# Altium schematic sheet Э3("All Documents",Physical)
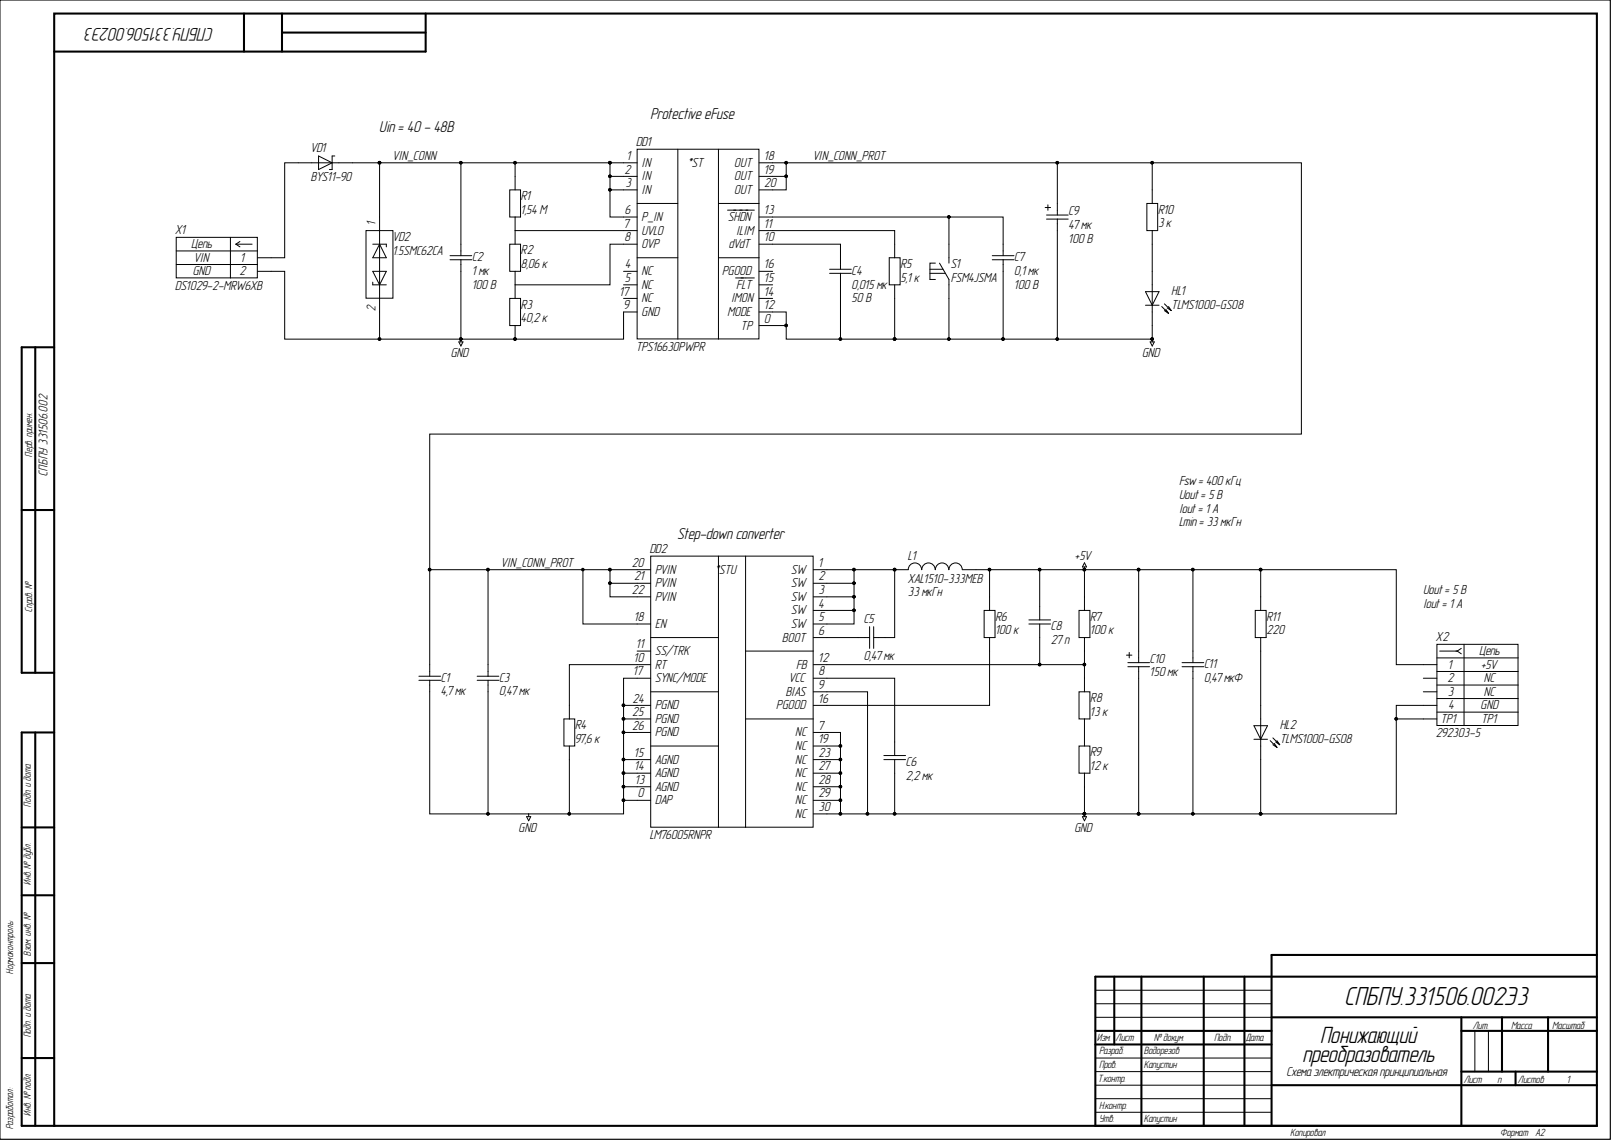
[diagram p0: Э3("All Documents",Physical)]

## Parts
C1  4,7 мк  [C1206]
C2  1 мк  [C1206]
C3  0,47 мк  [C1206]
C4  0,015 мк  Номинальное напряжение: 50 В  [C0603]
C5  0,47 мк  [C1206]
C6  2,2 мк  [C0603]
C7  0,1 мк  Номинальное напряжение: 100 В  [C0603]
C8  27 п  [C0402]
C9  47 мк  Номинальное напряжение: 100 В  [CAPAE-1050X1050X1250]
C10  150 мк  [CAPAE-660X660X580]
C11  0,47 мкФ  [C0402]
DD1  TPS16630PWPR  [HTSSOP-20]
DD2  LM76005RNPR  [WQFN-30]
HL1  TLMS1000-GS08  [HL0603]
HL2  TLMS1000-GS08  [HL0603]
L1  XAL1510-333MEB  Номинал: 33 мкГн  [XAL-2-1620X1520X1100]
R1  1,54 М  [R0603]
R2  8,06 к  [R0603]
R3  40,2 к  [R0603]
R4  97,6 к  [R0603]
R5  5,1 к  [R0603]
R6  100 к  [R0603]
R7  100 к  [R0603]
R8  13 к  [R0603]
R9  12 к  [R0603]
R10  3 к  [R0603]
R11  220  [R0603]
S1  FSM4JSMA  [FSM4JSMA]
VD1  BYS11-90  [DO-214AC]
VD2  1.5SMC62CA  [DO-214AB]
X1  DS1029-2-MRW6XB  Contact_2: GND  Contact_1: VIN  [DS1029-2-MRW6XB]
X2  292303-5  Contact_4: GND  Contact_3: NC  Contact_1: +5V  [292303-5]

## Nets
+5V: C8.2 C10.1 C11.1 L1.2 R6.2 R7.2 R11.2 X2.1
GND: C1.2 C2.2 C3.1 C4.2 C6.1 C7.2 C9.2 C10.2 C11.2 DD1.0(TP) DD1.9(GND) DD1.12(MODE) DD2.0(DAP) DD2.7(NC) DD2.9(BIAS) DD2.13(AGND) DD2.14(AGND) DD2.15(AGND) DD2.17(SYNC/MODE) DD2.19(NC) DD2.23(NC) DD2.24(PGND) DD2.25(PGND) DD2.26(PGND) DD2.27(NC) DD2.28(NC) DD2.29(NC) DD2.30(NC) HL1.1 HL2.1 R3.1 R4.2 R5.2 R9.1 S1.1 VD2.2 X1.2 X2.4 X2.TP1
VIN_CONN: C2.1 DD1.1(IN) DD1.2(IN) DD1.3(IN) DD1.6(P_IN) R1.2 VD1.1 VD2.1
VIN_CONN_PROT: C1.1 C3.2 C9.1 DD1.18(OUT) DD1.19(OUT) DD1.20(OUT) DD2.18(EN) DD2.20(PVIN) DD2.21(PVIN) DD2.22(PVIN) R10.2
NetC4_1: C4.1 DD1.10(dVdT)
NetC5_1: C5.1 DD2.1(SW) DD2.2(SW) DD2.3(SW) DD2.4(SW) DD2.5(SW) L1.1
NetC5_2: C5.2 DD2.6(BOOT)
NetC6_2: C6.2 DD2.8(VCC)
NetC7_1: C7.1 DD1.13(SHDN) S1.2
NetC8_1: C8.1 DD2.12(FB) R7.1 R8.2
NetDD1_4: DD1.4(NC)
NetDD1_5: DD1.5(NC)
NetDD1_7: DD1.7(UVLO) R1.1 R2.2
NetDD1_8: DD1.8(OVP) R2.1 R3.2
NetDD1_11: DD1.11(ILIM) R5.1
NetDD1_14: DD1.14(IMON)
NetDD1_15: DD1.15(FLT)
NetDD1_16: DD1.16(PGOOD)
NetDD1_17: DD1.17(NC)
NetDD2_10: DD2.10(RT) R4.1
NetDD2_11: DD2.11(SS/TRK)
NetDD2_16: DD2.16(PGOOD) R6.1
NetHL1_2: HL1.2 R10.1
NetHL2_2: HL2.2 R11.1
NetR8_1: R8.1 R9.2
NetVD1_2: VD1.2 X1.1
NetX2_2: X2.2
NetX2_3: X2.3

## Library data of the parts
C1 = c0043: =Описание; Фамилия: Водорезов; Рабочие температуры: от -55 °С до +125 °С; Производитель: Samsung electro-mechanics; Отклонение: ±10 %; Описание: Конденсатор чип 1206 4,7 мкФ 100 В ±10 %; Номинальное напряжение: 100 В; Наименование: CL31B475KAHVPNE; Корпус: 1206; Database Table Name: Конденсаторы$; Номинал: 4,7 мк; ID: c0043; Description: Конденсатор чип 1206 4,7 мкФ 100 В ±10 %; Component Kind: Standard; Library Name: Database_Students.DbLib
C2 = c0024: =Описание; Фамилия: Ларионов; Рабочие температуры: от -55 °С до +125 °С; Производитель: YAGEO Corp.; Отклонение: ±10 %; Описание: Конденсатор чип 1206 1 мкФ 100 В ±10 %; Номинальное напряжение: 100 В; Наименование: CC1206KKX7R0BB105; Корпус: 1206; Database Table Name: Конденсаторы$; Номинал: 1 мк; Напряжение: 100 В; ID: c0024; HelpURL: https://www.yageo.com/upload/media/product/productsearch/datasheet/mlcc/UPY-NP0X7R_MV_100-to-630V_22.pdf; Description: Конденсатор чип 1206 1 мкФ 100 В ±10 %; Component Kind: Standard; Library Name: Database_Students.DbLib
C3 C5 = c0008: =Описание; Фамилия: Водорезов; Рабочие температуры: от -55 °С до +125 °С; Производитель: Samsung electro-mechanics; Отклонение: ±10 %; Описание: Конденсатор чип 1206 0,47 мкФ 100 В ±10 %; Номинальное напряжение: 100 В; Наименование: CL31B474KCHWPNE; Корпус: 1206; Database Table Name: Конденсаторы$; Номинал: 0,47 мк; ID: c0008; HelpURL: http://product.samsungsem.com/mlcc/CL31B474KCHWPN.do; Description: Конденсатор чип 1206 0,47 мкФ 100 В ±10 %; Component Kind: Standard; Library Name: Database_Students.DbLib
C4 = c0014: =Описание; Фамилия: Жернаков; Рабочие температуры: от -55 °С до +125 °С; Производитель: YAGEO Corp.; Отклонение: ±5 %; Описание: Конденсатор чип 0603 0,015 мкФ 50 В ±5 %; Наименование: CC0603JPX7R9BB153; Корпус: 0603; Database Table Name: Конденсаторы$; Номинал: 0,015 мк; Напряжение: 50 В; ID: c0014; HelpURL: https://www.yageo.com/upload/media/product/productsearch/datasheet/mlcc/UPY-GPHC_X7R_6.3V-to-50V_20.pdf; Description: Конденсатор чип 0603 0,015 мкФ 50 В ±5 %; Component Kind: Standard; Library Name: Database_Students.DbLib
C6 = c0012: =Описание; Фамилия: Самарин; Рабочие температуры: от -55 °С до +85 °С; Производитель: YAGEO Corp.; Отклонение: ±10 %; Описание: Конденсатор чип 0603 2,2 мкФ 50 В ±10 %; Номинальное напряжение: 50 В; Наименование: CC0603KRX5R9BB225; Корпус: 0603; Database Table Name: Конденсаторы$; Номинал: 2,2 мк; ID: c0012; HelpURL: https://www.yageo.com/upload/media/product/productsearch/datasheet/mlcc/UPY-GPHC_X5R_4V-to-50V_26.pdf; Description: Конденсатор чип 0603 2,2 мкФ 50 В ±10 %; Component Kind: Standard; Library Name: Database_Students.DbLib
C7 = c0018: =Описание; Фамилия: Ларионов; Рабочие температуры: от -55 °С до +125 °С; Производитель: YAGEO Corp.; Отклонение: ±10 %; Описание: Конденсатор чип 0603 0,1 мкФ 100 В ±10 %; Наименование: CC0603KRX7R0BB104; Корпус: 0603; Database Table Name: Конденсаторы$; Номинал: 0,1 мк; Напряжение: 100 В; ID: c0018; HelpURL: https://www.yageo.com/upload/media/product/productsearch/datasheet/mlcc/UPY-NP0X7R_MV_100-to-630V_22.pdf; Description: Конденсатор чип 0603 0,1 мкФ 100 В ±10 %; Component Kind: Standard; Library Name: Database_Students.DbLib
C8 = c0001: =Описание; Фамилия: Соколов; Рабочие температуры: от -55 °С до +125 °С; Производитель: Samsung electro-mechanics; Отклонение: ±5 %; Описание: Конденсатор чип 0402 27 пФ 50 В ±5 %; Номинальное напряжение: 50 В; Наименование: CL05C270JB5NNNC; Корпус: 0402; Database Table Name: Конденсаторы$; Номинал: 27 п; ID: c0001; HelpURL: http://product.samsungsem.com/mlcc/CL05C270JB5NNN.do; Description: Конденсатор чип 0402 27 пФ 50 В ±5 %; Component Kind: Standard; Library Name: Database_Students.DbLib
C9 = c0041: =Описание; Фамилия: Ларионов; Рабочие температуры: от -55 °С до +125 °С; Производитель: Vishay Intertechnology, Inc.; Отклонение: ±20 %; Описание: Конденсатор электролитический 47 мкФ 100 В ±20 %; Наименование: MAL214699904E3; Корпус: CAPAE (10,5 x 10,5 x 12,5); Database Table Name: Конденсаторы$; Номинал: 47 мк; Напряжение: 100 В; ID: c0041; HelpURL: https://www.vishay.com/capacitors/list/product-28403/; Description: Конденсатор электролитический 47 мкФ 100 В ±20 %; Component Kind: Standard; Library Name: Database_Students.DbLib
C10 = c0042: =Описание; Фамилия: Водорезов; Рабочие температуры: от -55 °С до +105 °С; Производитель: Panasonic Corporation; Отклонение: ±20 %; Описание: Конденсатор электролитический 150 мкФ 10 В ±20 %; Номинальное напряжение: 10 В; Наименование: EEEFK1A151AL; Корпус: CAPAE (6,6 x 6,6 x 5,8); Database Table Name: Конденсаторы$; Номинал: 150 мк; ID: c0042; HelpURL: https://industrial.panasonic.com/ww/products/capacitors/aluminum-capacitors/aluminum-cap-smd/models/EEEFK1A151AL; Description: Конденсатор электролитический 150 мкФ 10 В ±20 %; Component Kind: Standard; Library Name: Database_Students.DbLib
C11 = c0036: =Описание; Фамилия: Ляпцев; Рабочие температуры: от -55 °С до +85 °С; Производитель: Murata Manufacturing Co., Ltd.; Отклонение: ±10 %; Описание: Конденсатор чип 0402 0,47 мкФ 6,3 В ±10 %; Номинальное напряжение: 6,3 В; Наименование: GRM155R60J474KE19D; Корпус: 0402; Database Table Name: Конденсаторы$; Номинал: 0,47 мкФ; ID: c0036; HelpURL: https://www.murata.com/en-us/products/productdetail?partno=GRM155R60J474KE19%23; Description: Конденсатор чип 0402 0,47 мкФ 6,3 В ±10 %; Component Kind: Standard; Library Name: Database_Students.DbLib
DD1 = dd0002: =Описание; Фамилия: Жернаков; Ток: от 0,6 А до 6 А; Рабочие температуры: от -40 °С до +125 °С; Производитель: Texas Instruments; Описание: Защитная микросхема eFuse 60 В и 6 А; Напряжение: от 4,5 В до 60 В; Максимальное напряжение: 67 В; Database Table Name: Защитные микросхемы$; Наименование: TPS16630PWPR; Корпус: HTSSOP-20; ID: dd0002; HelpURL: https://www.ti.com/product/TPS1663; Description: Защитная микросхема eFuse 60 В и 6 А; Component Kind: Standard; Library Name: Database_Students.DbLib
DD2 = dd0004: =Описание; Частота переключения: от 200 кГц до 500 КГц; Фамилия: Водорезов; Рабочие температуры: от -40 °С до +125 °С; Производитель: Texas Instruments; Описание: Понижающий преобразователь напряжения 60 В и 5 А; Коммутируемый ток: от 0 А до 5 А; Выходное напряжение: от 1 В до 57 В; Входное напряжение: от 3,5 В до 60 В; Database Table Name: Преобразователи напряжения$; Наименование: LM76005RNPR; Корпус: WQFN-30; ID: dd0004; HelpURL: https://www.ti.com/product/LM76005; Description: Понижающий преобразователь напряжения 60 В и 5 А; Component Kind: Standard; Library Name: Database_Students.DbLib
HL1 HL2 = hl0001: =Описание; Цвет: Red; Фамилия: Ларионов; Рассеиваемая мощность: 40 мВт; Рабочие температуры: от -40 °С до +100 °С; Производитель: Vishay Intertechnology, Inc.; Описание: Светодиод чип 0603 красный; Номинальный прямой ток: 15 мА; Номинальное падение напряжения: 1,8 В; Номинальная сила света: 4 мкд; Номинальная длина волны: 628 нм; Корпус: 0603; Database Table Name: Светодиоды$; Наименование: TLMS1000-GS08; ID: hl0001; HelpURL: https://www.vishay.com/leds/list/product-83172/; Description: Светодиод чип 0603 красный; Component Kind: Standard; Library Name: Database_Students.DbLib
L1 = l0001: =Описание; Частота резонанса: 5,8 МГц; Фамилия: Водорезов; Ток насыщения: 16,7 А; Рабочие температуры: от -40 °С до +125 °С; Рабочее напряжение: от 0 В до 60 В; Производитель: Coilcraft Inc.; Отклонение: ±20 %; Описание: Индуктивность 33 мкГн ±20 %; Номинальный ток (при нагреве на 20 °С/40 °С): 8,6 А; Номинальное активное сопротивление: 18,7 мОм; Максимальное активное сопротивление: 20 мОм; Корпус: 16,2 x 15,2 x 11; Database Table Name: Индуктивности$; Наименование: XAL1510-333MEB; Индуктивность: 33 мкГн; ID: l0001; HelpURL: https://www.coilcraft.com/en-us/products/power/shielded-inductors/molded-inductor/xal/xal1510; Description: Индуктивность 33 мкГн ±20 %; Component Kind: Standard; Library Name: Database_Students.DbLib
R1 = r0042: =Описание; Фамилия: Жернаков; Рабочие температуры: от -55 °С до +155 °С; Производитель: YAGEO Corp.; Отклонение: ±1 %; Описание: Резистор чип 0603 1,54 МОм ±1 % 0,1 Вт; Номинальный ток: 1 А; Номинальное напряжение: 75 В; Наименование: RC0603FR-071M54L; Корпус: 0603; Database Table Name: Резисторы$; Номинал: 1,54 М; ID: r0042; HelpURL: https://www.yageo.com/upload/media/product/productsearch/datasheet/rchip/PYu-RC_Group_51_RoHS_L_11.pdf; Description: Резистор чип 0603 1,54 МОм ±1 % 0,1 Вт; Component Kind: Standard; Library Name: Database_Students.DbLib
R2 = r0020: =Описание; Фамилия: Жернаков; Рабочие температуры: от -55 °С до +155 °С; Производитель: YAGEO Corp.; Отклонение: ±1 %; Описание: Резистор чип 0603 8,06 кОм ±1 % 0,1 Вт; Номинальный ток: 1 А; Номинальное напряжение: 75 В; Наименование: RC0603FR-078K06L; Корпус: 0603; Database Table Name: Резисторы$; Номинал: 8,06 к; ID: r0020; HelpURL: https://www.yageo.com/upload/media/product/productsearch/datasheet/rchip/PYu-RC_Group_51_RoHS_L_11.pdf; Description: Резистор чип 0603 8,06 кОм ±1 % 0,1 Вт; Component Kind: Standard; Library Name: Database_Students.DbLib
R3 = r0028: =Описание; Фамилия: Жернаков; Рабочие температуры: от -55 °С до +155 °С; Производитель: YAGEO Corp.; Отклонение: ±1 %; Описание: Резистор чип 0603 40,2 кОм ±1 % 0,1 Вт; Номинальный ток: 1 А; Номинальное напряжение: 75 В; Наименование: RC0603FR-0740K2L; Корпус: 0603; Database Table Name: Резисторы$; Номинал: 40,2 к; ID: r0028; HelpURL: https://www.yageo.com/upload/media/product/productsearch/datasheet/rchip/PYu-RC_Group_51_RoHS_L_11.pdf; Description: Резистор чип 0603 40,2 кОм ±1 % 0,1 Вт; Component Kind: Standard; Library Name: Database_Students.DbLib
R4 = r0024: =Описание; Фамилия: Водорезов; Рабочие температуры: от -55 °С до +155 °С; Производитель: YAGEO Corp.; Отклонение: ±1 %; Описание: Резистор чип 0603 97.6 кОм ±1 % 0,2 Вт; Номинальный ток: 1 А; Номинальное напряжение: 75 В; Наименование: RC0603FR-0797K6L; Корпус: 0603; Database Table Name: Резисторы$; Номинал: 97,6 к; ID: r0024; HelpURL: https://www.yageo.com/upload/media/product/productsearch/datasheet/rchip/PYu-RC_Group_51_RoHS_L_11.pdf; Description: Резистор чип 0603 97.6 кОм ±1 % 0,2 Вт; Component Kind: Standard; Library Name: Database_Students.DbLib
R5 = r0019: =Описание; Фамилия: Жернаков; Рабочие температуры: от -55 °С до +155 °С; Производитель: YAGEO Corp.; Отклонение: ±1 %; Описание: Резистор чип 0603 5,1 кОм ±1 % 0,1 Вт; Номинальный ток: 1 А; Номинальное напряжение: 75 В; Наименование: RC0603FR-075K1L; Корпус: 0603; Database Table Name: Резисторы$; Номинал: 5,1 к; ID: r0019; HelpURL: https://www.yageo.com/upload/media/product/productsearch/datasheet/rchip/PYu-RC_Group_51_RoHS_L_11.pdf; Description: Резистор чип 0603 5,1 кОм ±1 % 0,1 Вт; Component Kind: Standard; Library Name: Database_Students.DbLib
R6 R7 = r0023: =Описание; Фамилия: Водорезов; Рабочие температуры: от -55 °С до +155 °С; Производитель: YAGEO Corp.; Отклонение: ±1 %; Описание: Резистор чип 0603 100 кОм ±1 % 0,2 Вт; Номинальный ток: 1 А; Номинальное напряжение: 75 В; Наименование: RC0603FR-07100KL; Корпус: 0603; Database Table Name: Резисторы$; Номинал: 100 к; ID: r0023; HelpURL: https://www.yageo.com/upload/media/product/productsearch/datasheet/rchip/PYu-RC_Group_51_RoHS_L_11.pdf; Description: Резистор чип 0603 100 кОм ±1 % 0,2 Вт; Component Kind: Standard; Library Name: Database_Students.DbLib
R8 = r0016: =Описание; Фамилия: Жернаков; Рабочие температуры: от -55 °С до +155 °С; Производитель: YAGEO Corp.; Отклонение: ±1 %; Описание: Резистор чип 0603 13 кОм ±1 % 0,1 Вт; Номинальный ток: 1 А; Номинальное напряжение: 75 В; Наименование: RC0603FR-0713KL; Корпус: 0603; Database Table Name: Резисторы$; Номинал: 13 к; ID: r0016; HelpURL: https://www.yageo.com/upload/media/product/productsearch/datasheet/rchip/PYu-RC_Group_51_RoHS_L_11.pdf; Description: Резистор чип 0603 13 кОм ±1 % 0,1 Вт; Component Kind: Standard; Library Name: Database_Students.DbLib
R9 = r0015: =Описание; Фамилия: Соколов; Рабочие температуры: от -55 °С до +155 °С; Производитель: YAGEO Corp.; Отклонение: ±1 %; Описание: Резистор чип 0603 12 кОм ±1 % 0,1 Вт; Номинальный ток: 1 А; Номинальное напряжение: 75 В; Наименование: AC0603FR-1012KL; Корпус: 0603; Database Table Name: Резисторы$; Номинал: 12 к; ID: r0015; HelpURL: https://www.yageo.com/upload/media/product/productsearch/datasheet/rchip/PYu-AC_51_RoHS_L_7.pdf; Description: Резистор чип 0603 12 кОм ±1 % 0,1 Вт; Component Kind: Standard; Library Name: Database_Students.DbLib
R10 = r0015: =Описание; Фамилия: Ларионов; Рабочие температуры: от -55 °С до +155 °С; Производитель: YAGEO Corp.; Отклонение: ±1 %; Описание: Резистор чип 0603 3 кОм ±1 % 0,1 Вт; Номинальный ток: 1 А; Номинальное напряжение: 75 В; Наименование: RC0603FR-073KL; Корпус: 0603; Database Table Name: Резисторы$; Номинал: 3 к; ID: r0015; HelpURL: https://www.yageo.com/upload/media/product/productsearch/datasheet/rchip/PYu-RC_Group_51_RoHS_L_11.pdf; Description: Резистор чип 0603 3 кОм ±1 % 0,1 Вт; Component Kind: Standard; Library Name: Database_Students.DbLib
R11 = r0009: =Описание; Фамилия: Ларионов; Рабочие температуры: от -55 °С до +155 °С; Производитель: YAGEO Corp.; Отклонение: ±1 %; Описание: Резистор чип 0603 220 Ом ±1 % 0,1 Вт; Номинальный ток: 1 А; Номинальное напряжение: 75 В; Наименование: RC0603FR-07220RL; Корпус: 0603; Database Table Name: Резисторы$; Номинал: 220; ID: r0009; HelpURL: https://www.yageo.com/upload/media/product/productsearch/datasheet/rchip/PYu-RC_Group_51_RoHS_L_11.pdf; Description: Резистор чип 0603 220 Ом ±1 % 0,1 Вт; Component Kind: Standard; Library Name: Database_Students.DbLib
S1 = sw0002: =Описание; Фамилия: Паньков; Ток нагрузки: 50 мА @ 24 В; Сопротивление контакта: 100 мОм; Рабочие температуры: от -35 °С до +85 °С; Промывка: Разрешена; Производитель: TE Connectivity; Описание: Тактовая кнопка поверхностного монтажа; Корпус: 6,00x6,00x4,98; Количество контактов: 1; Database Table Name: Переключатели$; Наименование: FSM4JSMA; ID: sw0002; HelpURL: https://www.te.com/usa-en/product-4-1437565-1.html; Description: Тактовая кнопка поверхностного монтажа; Component Kind: Standard; Library Name: Database_Students.DbLib
VD1 = vd0005: =Описание; Фамилия: Водорезов; Рабочие температуры: от -55 °С до +125 °С; Производитель: Vishay Intertechnology, Inc.; Пиковое прямое напряжение: 0,75 В; Описание: Диод Шоттки 90 В 1,5 А; Макс допустимый средний прямой ток: 1,5 А; Импульсное обратное напряжение: 90 В; Database Table Name: Диоды$; Наименование: BYS11-90; Корпус: DO-214AC; ID: vd0005; Description: Диод Шоттки 90 В 1,5 А; Component Kind: Standard; Library Name: Database_Students.DbLib
VD2 = vd0004: =Описание; Фамилия: Ларионов; Производитель: Littelfuse, Inc.; Описание: Двунаправленная диодная сборка для подавления помех, 53 В; Database Table Name: Диодные сборки$; Наименование: 1.5SMC62CA; Корпус: DO-214AB; ID: vd0004; HelpURL: https://www.littelfuse.com/products/tvs-diodes/surface-mount/1_5smc.aspx; Description: Двунаправленная диодная сборка для подавления помех, 53 В; Component Kind: Standard; Library Name: Database_Students.DbLib
X1 = x0006: =Описание; Фамилия: Ларионов; Производитель: Connfly Electronic Co. LTD.; Описание: CWF 2 pin Type B 2,5 мм вилка угловой; Database Table Name: Разъемы$; Наименование: DS1029-2-MRW6XB; ID: x0006; HelpURL: http://www.connfly.com/productshow.aspx?id=352; Description: CWF 2 pin Type B 2,5 мм вилка угловой; Component Kind: Standard; Library Name: Database_Students.DbLib
X2 = x0003: =Описание; Фамилия: Ларионов; Производитель: TE Connectivity; Описание: USB 2.0 Type A гнездо; Database Table Name: Разъемы$; Наименование: 292303-5; ID: x0003; HelpURL: https://www.te.com/usa-en/product-292303-5.html; Description: USB 2.0 Type A гнездо; Contact_2: NC; Component Kind: Standard; Library Name: Database_Students.DbLib
